# Altium schematic sheet Power.SchDoc
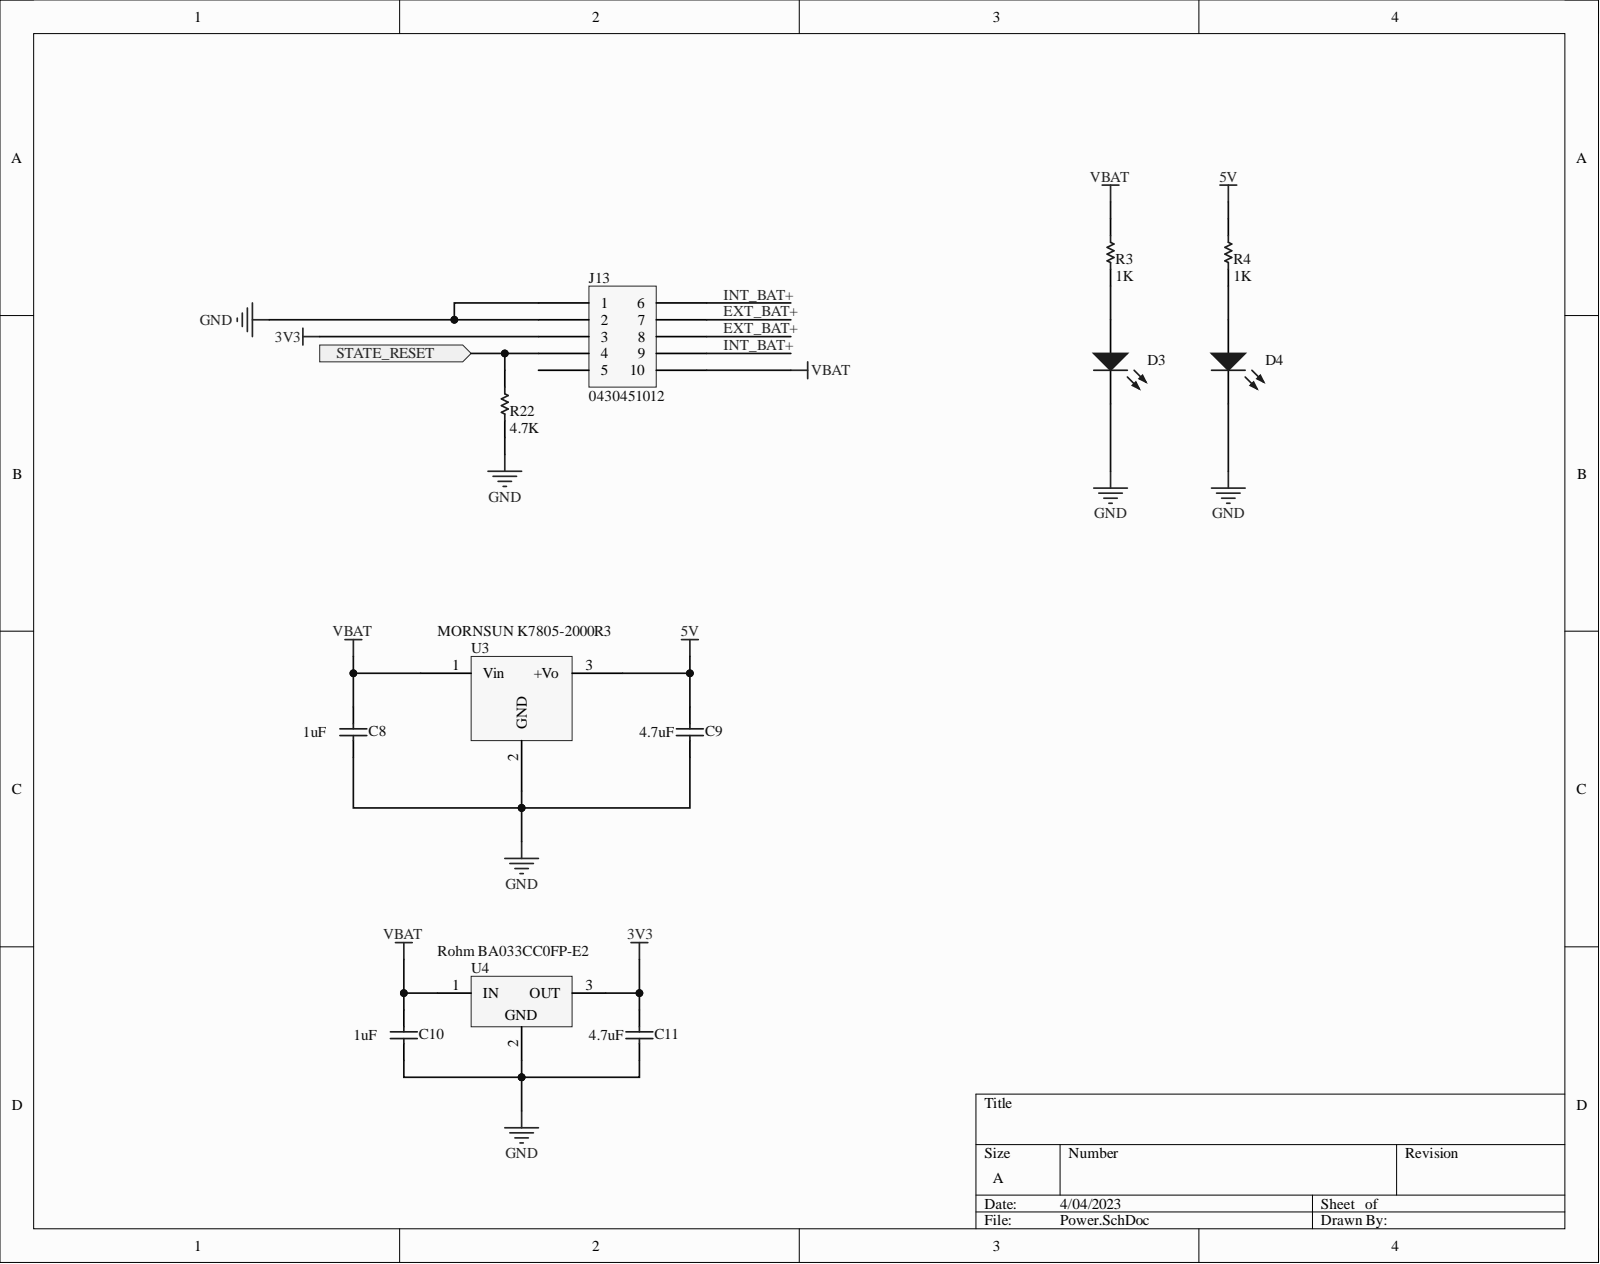
[diagram p0: Power.SchDoc]

## Parts
C8  Capacitance: 1uF  [CAPC2013X94X50NL20T25]  (hidden comment: AVX Interconnect / Elco 08051C182KAT2A)
C9  Capacitance: 4.7uF  [CAPC2013X94X50NL20T25]  (hidden comment: AVX Interconnect / Elco 08051C182KAT2A)
C10  Capacitance: 1uF  [CAPC2013X94X50NL20T25]  (hidden comment: AVX Interconnect / Elco 08051C182KAT2A)
C11  Capacitance: 4.7uF  [CAPC2013X94X50NL20T25]  (hidden comment: AVX Interconnect / Elco 08051C182KAT2A)
D3  Dialight 598-8191-107F  [PCB-8gfa86omdps060zikj8u-1]  (value not drawn)
D4  Dialight 598-8191-107F  [PCB-8gfa86omdps060zikj8u-1]  (value not drawn)
J13  0430451012  [0430451012-Footprint-1]
R3  Resistance: 1K  [RESC2013X70X40ML20T20]  (hidden comment: Panasonic ERJ-6GEYJ911V)
R4  Resistance: 1K  [RESC2013X70X40ML20T20]  (hidden comment: Panasonic ERJ-6GEYJ911V)
R22  Resistance: 4.7K  [RESC2013X70X40ML20T20]  (hidden comment: Panasonic ERJ-6GEYJ911V)
U3  MORNSUN K7805-2000R3  Manufacturer Part Number: K7805-2000R3  [MORNSUN K7805-2000R3-Footprint-1]
U4  Rohm BA033CC0FP-E2  Manufacturer Part Number: BA033CC0FP-E2  [PCB-1et4yb7ygjadz4hbptdi-1]

## Ports
STATE_RESET

## Nets
3V3: C11.2 J13.3 U4.3
5V: C9.2 R4.1 U3.3
GND: C8.1 C9.1 C10.1 C11.1 D3.K D4.K J13.1 J13.2 R22.2 U3.2 U4.2
VBAT: C8.2 C10.2 J13.10 R3.1 U3.1 U4.1
EXT_BAT+: J13.7 J13.8
INT_BAT+: J13.6 J13.9
STATE_RESET: J13.4 R22.1 ; port STATE_RESET
NetD3_A: D3.A R3.2
NetD4_A: D4.A R4.2

Not connected: J13 5

## Library data of the parts
C8-C11 = CMP-128428-2: CAP CER 1800PF 100V 10% X7R 0805; Case/Package (SI): 2012; Lead-Free Status: Lead Free; Voltage Rating (DC): 100.0 V; Capacitance Tolerance: ±10%; Case/Package: 0805; Component Kind: Standard; Dielectric Characteristic: X7R; Library Name: My Personal Space; Manufacturer Part Number: 08051C182KAT2A; Number of Pins: 2; Octopart Url: https://octopart.com/08051c182kat2a-avx+interconnect+%2F+elco-2160389; OctopartID: 0d2cc406df4fd2eb; Packaging: Tape & Reel (TR); RoHS: Compliant
D3 D4 = CMP-90265921f4414fe1-1: 598 Series Blue 2 x 1.25 x 0.7 mm 140° Water Clear 140 mcd 3.2 V SMT LED; Case/Package (SI): 2012; Forward Voltage: 3.20 V; Lead-Free Status: Lead Free; Lens Type: Non-Diffused; Luminous Intensity: 140 mcd; Wavelength: 475 nm, 473 nm; Case/Package: 0805; Color: Blue; Component Kind: Standard; Library Name: My Personal Space; Manufacturer Part Number: 598-8191-107F; Mounting Style: Surface Mount; Number of Pins: 2; Octopart URL: http://octopart.com/598-8191-107f-dialight-868609; OctopartID: 90265921f4414fe1; Packaging: Reel; RoHS: Compliant; Size-Height: 700 µm; Size-Length: 2.00 mm; Size-Width: 1.25 mm; Viewing Angle: 140 °
J13 = CMP-qg0yj5o9nz0t2poknx3j-1: CONN HEADER VERT 10POS 3MM; Manufacturer part number: 0430451028; Component Kind: Standard; CustomComponentID: qg0yj5o9nz0t2poknx3j; Digkey URL: https://www.digikey.com/en/products/detail/molex/0430451028/3310216; Library Name: My Personal Space
R3 R4 R22 = CMP-3670835-2: Thick Film Resistors - SMD 0805 910ohms 5% Tol; Case/Package (SI): 2012; Lead-Free Status: Lead Free; Power Rating: 0.125 W; Voltage Rating (DC): 150.0 V; Case/Package: 0805; Component Kind: Standard; Library Name: My Personal Space; Lifecycle Status: Not Listed by Manufacturer; Manufacturer Part Number: ERJ-6GEYJ911V; Mounting Style: Surface Mount; Octopart Url: https://octopart.com/erj-6geyj911v-panasonic-55423073; OctopartID: 40418a06d5469f86; Packaging: Cut Tape (CT); REACH SVHC Compliance: No SVHC; Resistance Tolerance: ±5%; RoHS: Compliant; Size-Height: 0.0006 m; Size-Length: 0.002 m; Size-Width: 0.00125 m
U3 = CMP-a3426ffebe0e7c85-2: wide i/p: 8V-36V, single o/p: 5V, 2A DIP; Component Kind: Standard; Library Name: My Personal Space; Octopart Url: https://octopart.com/k7805-2000r3-mornsun-95828010; OctopartID: a3426ffebe0e7c85
U4 = CMP-e3662a64c8413ca1-10: Fixed LDO Voltage Regulator, 4V to 25V, 300mV Dropout, 3.3Vout, 1Aout, TO-252-3; Input Voltage (DC): 35.0 V (max); Lead-Free Status: Lead Free; Output Current: 1.00 A; Case/Package: TO-252; Component Kind: Standard; Library Name: My Personal Space; Mounting Style: Surface Mount; Number of Pins: 3; Octopart URL: http://octopart.com/ba033cc0fp-e2-rohm-527937; OctopartID: e3662a64c8413ca1; Packaging: Bulk; RoHS: Compliant
